# Altium schematic sheet Switch_board_right.SchDoc
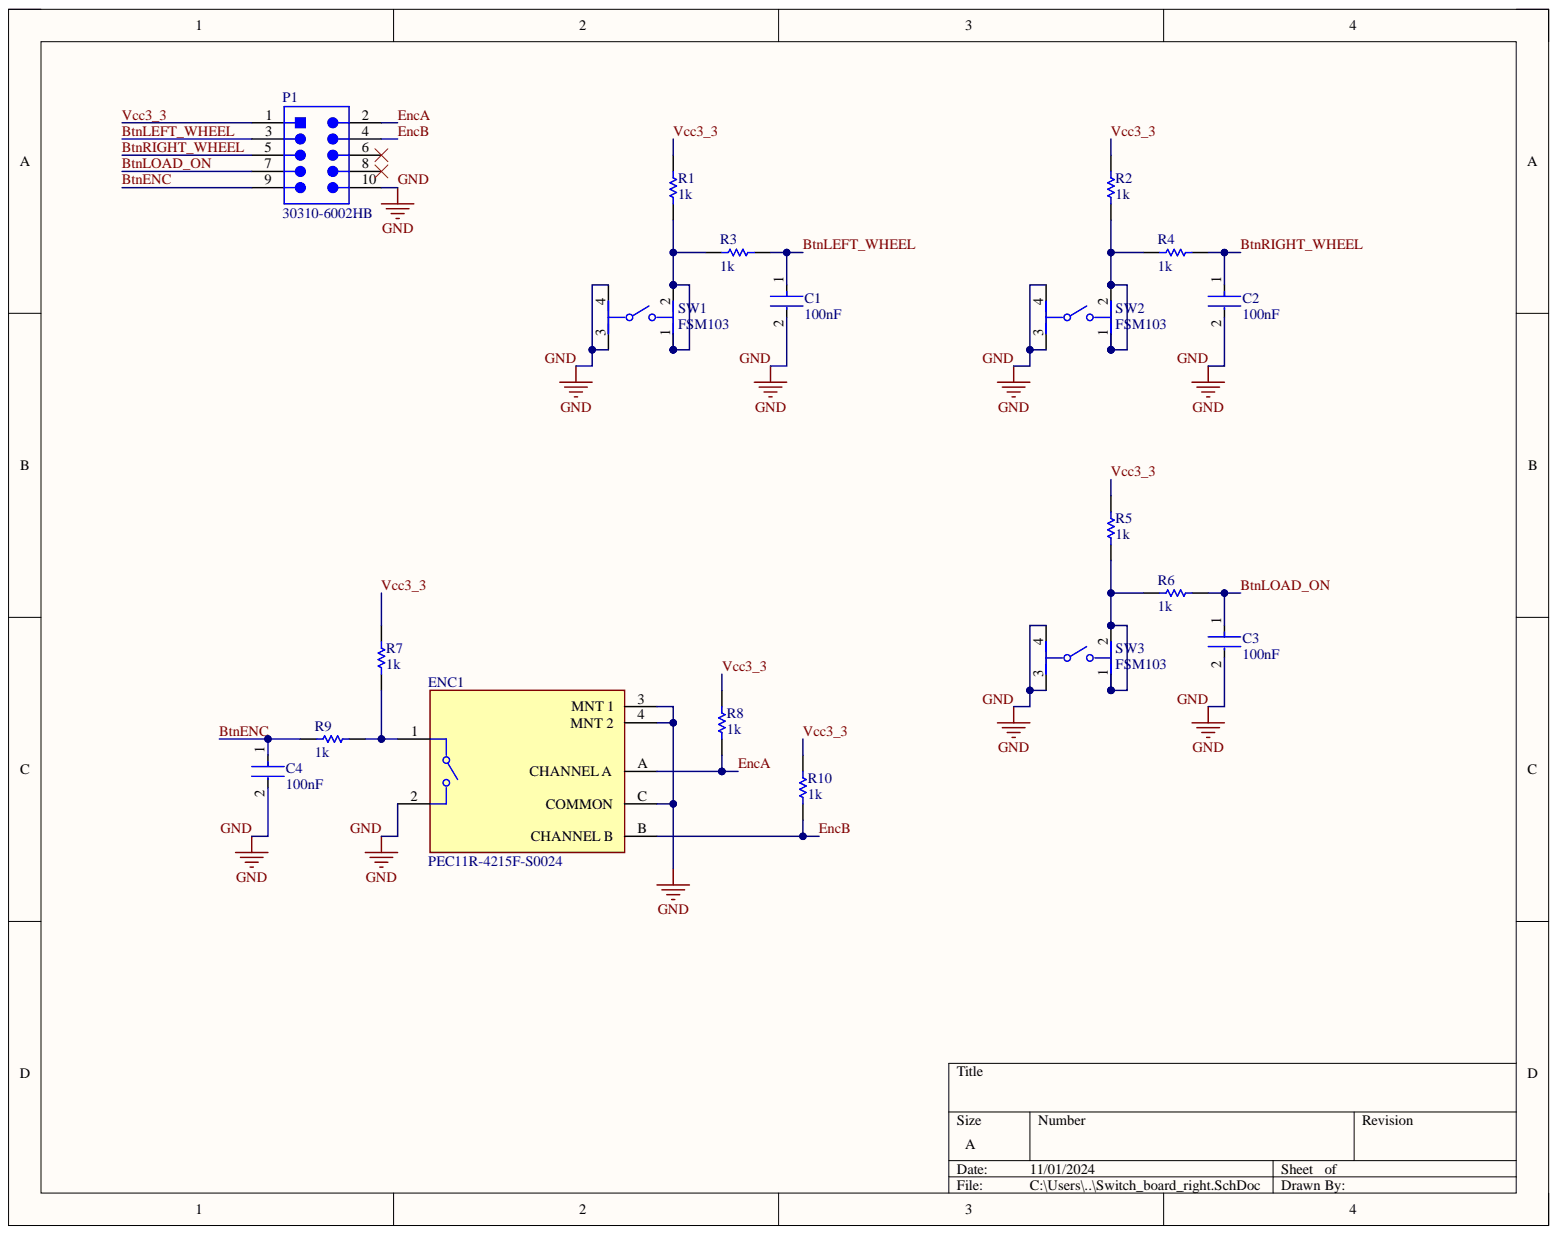
[diagram p0: Switch_board_right.SchDoc]

## Parts
C1  100nF  [FP-0805-L_2_01_0_2-W_1_25-IPC_B]
C2  100nF  [FP-0805-L_2_01_0_2-W_1_25-IPC_B]
C3  100nF  [FP-0805-L_2_01_0_2-W_1_25-IPC_B]
C4  100nF  [FP-0805-L_2_01_0_2-W_1_25-IPC_B]
ENC1  PEC11R-4215F-S0024  [FP-PEC11R-4215F-S0024-MFG]
P1  30310-6002HB  [3M-30310-6002HB-10_V]
R1  1k  [RESC2013X50X30LL20T20]
R2  1k  [RESC2013X50X30LL20T20]
R3  1k  [RESC2013X50X30LL20T20]
R4  1k  [RESC2013X50X30LL20T20]
R5  1k  [RESC2013X50X30LL20T20]
R6  1k  [RESC2013X50X30LL20T20]
R7  1k  [RESC2013X50X30LL20T20]
R8  1k  [RESC2013X50X30LL20T20]
R9  1k  [RESC2013X50X30LL20T20]
R10  1k  [RESC2013X50X30LL20T20]
SW1  FSM103  [FP-FSM103-MFG]
SW2  FSM103  [FP-FSM103-MFG]
SW3  FSM103  [FP-FSM103-MFG]

## Nets
BtnENC: C4.1 P1.9 R9.2
BtnLEFT_WHEEL: C1.1 P1.3 R3.1
BtnLOAD_ON: C3.1 P1.7 R6.1
BtnRIGHT_WHEEL: C2.1 P1.5 R4.1
EncA: ENC1.A(CHANNEL_A) P1.2 R8.1
EncB: ENC1.B(CHANNEL_B) P1.4 R10.1
GND: C1.2 C2.2 C3.2 C4.2 ENC1.2 ENC1.3(MNT_1) ENC1.4(MNT_2) ENC1.C(COMMON) P1.10 SW1.3 SW1.4 SW2.3 SW2.4 SW3.3 SW3.4
Vcc3_3: P1.1 R1.2 R2.2 R5.2 R7.2 R8.2 R10.2
NetENC1_1: ENC1.1 R7.1 R9.1
NetR1_1: R1.1 R3.2 SW1.1 SW1.2
NetR2_1: R2.1 R4.2 SW2.1 SW2.2
NetR5_1: R5.1 R6.2 SW3.1 SW3.2

Not connected: P1 6 8

## Library data of the parts
C1-C4 = CMP-2007-04174-2: General Purpose Ceramic Capacitor, 0805, 100nF, 10%, X7R, 15%, 100V; Capacitance: 100nF; Case Code (Imperial): 0805; Case Code (Metric): 2012; Case/Package: 0805; Component Kind: Standard; Construction: Flat; Contact Plating: Tin; Depth: 1.25mm; Dielectric: X7R; Dielectric Material: Ceramic; Height: 1.4mm; Lead Free: Lead Free; Length: 2.01mm; Library Name: Altium Content Vault; Max Operating Temperature: 125°C; Min Operating Temperature: -55°C; Mount: Surface Mount; Number of Pins: 2; Package Quantity: 2000; Packaging: Tape and Reel; Radiation Hardening: No; REACH SVHC: No SVHC; RoHS Compliant: Yes; Series: -; Termination: SMD/SMT; Thickness: 0.055inch; Tolerance: 10%; Voltage: 100V; Voltage Rating: 100V; Voltage Rating (DC): 100V; Width: 0.049inch
ENC1 = CMP-1747-00012-2: ROTARY ENCODER MECHANICAL 24PPR; Built in Switch: Yes; Hole Diameter: 1.8mm; Number of Channels: 2; Output Type: Quadrature; Pulses per Revolution: 24; Component Kind: Standard; Detent: Yes; Encoder Type: Mechanical; Height: 13.4mm; Length: 21.5mm; Library Name: Altium Content Vault; Max Operating Temperature: 70°C; Min Operating Temperature: -30°C; Mount: Through Hole; Orientation: Vertical; Package Quantity: 150; Packaging: Bulk; Radiation Hardening: No; REACH SVHC: No SVHC; RoHS Compliant: Yes; Series: PEC11R; Termination: PC Pins; Type: Mechanical; Width: 12.5mm
P1 = CMP-2000-05031-1: Male Header, Pitch 2.54 mm, 2 x 5 Position, Height 9.3 mm, Tail Length 2.79 mm, -55 to 105 degC, RoHS, Bulk; Manufacturer: 3M; Brand: 3MElectronicSolutionsDivision; Current Rating: 1A; Mating Post Length: 5.99mm; Mounting Angle: Straight; Number of Positions: 10Position; Pitch: 2.54mm; Component Kind: Standard; Contact Gender: Pin(Male); Contact Material: Brass; Contact Plating: Gold; Datasheet URL: http://datasheet.octopart.com/30310-6002HB-3M-datasheet-11537585.pdf; Datasheet Version: Apr-12; Factory Pack Quantity: 1000; Housing Material: Polyester; Library Name: Altium Content Vault; Manufacturer URL: http://www.3m.com/; Mounting Style: StraightPin; Number of Rows: 2Row; Packaging: Tray; Product: Headers; Product Category: Headers&WireHousings; RoHS: Details; Row Spacing: 2.54mm; Series: 303; Termination Post Length: 2.79mm; Termination Style: ThroughHole; Type: Shrouded
R1-R10 = CMP-2001-04896-1: Manufacturer: Vishay Dale; Part Number: CRCW08050000Z0EA; Component Kind: Standard; Library Name: Altium Content Vault
SW1-SW3 = CMP-03407-000037-1: SWITCH TACTILE SPST-NO 0.05A 24V; Actuator Color: Black; Actuator Length: 3.4mm; Actuator Material: Polyester; Contact Current Rating: 50mA; Contact Resistance: 100mR; Electrical Life: 100000Cycles; Illumination Type: Non-Illuminated; Max Voltage Rating (DC): 12V; Operating Force: 1.57N; Switch Function: Off-Mom; Switch Travel: 0.3mm; Throw Configuration: SPST; Component Kind: Standard; Contact Material: Brass; Contact Plating: Silver; Current Rating: 50mA; Depth: 14.1mm; Length: 12mm; Library Name: Altium Content Vault; Max Current Rating: 50mA; Max Operating Temperature: 85°C; Mechanical Life: 100000Cycles; Min Operating Temperature: -35°C; Mount: Through Hole; Orientation: Straight; Package Quantity: 400; Packaging: Loose Piece; Radiation Hardening: No; RoHS Compliant: Yes; Series: FSM100 ~ 104; Termination: PC Pins; Type: Switch; Voltage Rating: 24V; Voltage Rating (DC): 12V; Width: 12mm
